# Altium schematic sheet ADC - Connectors.SchDoc
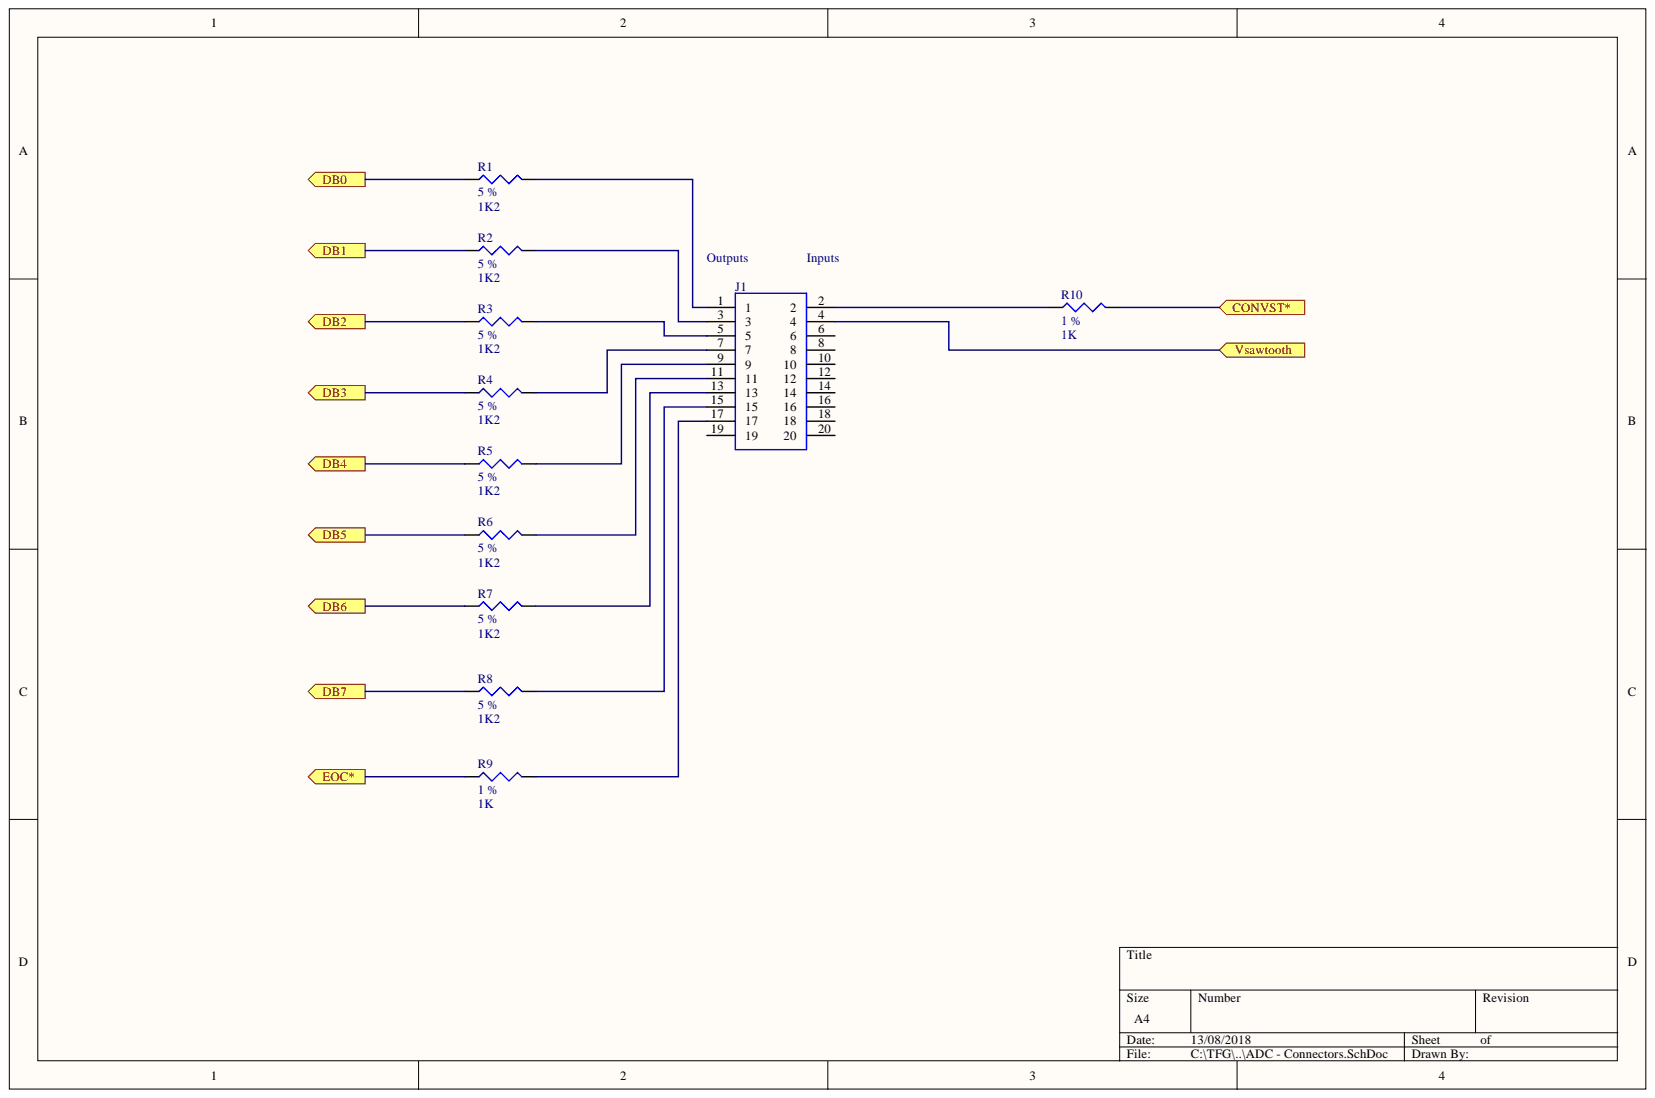
[diagram p0: ADC - Connectors.SchDoc]

## Parts
J1  IDC Connector  [IDC Connector]  (value not drawn)
R1  5 %  value 1K2  [Resistance (MM:1005) (IM: 0402)]
R2  5 %  value 1K2  [Resistance (MM:1005) (IM: 0402)]
R3  5 %  value 1K2  [Resistance (MM:1005) (IM: 0402)]
R4  5 %  value 1K2  [Resistance (MM:1005) (IM: 0402)]
R5  5 %  value 1K2  [Resistance (MM:1005) (IM: 0402)]
R6  5 %  value 1K2  [Resistance (MM:1005) (IM: 0402)]
R7  5 %  value 1K2  [Resistance (MM:1005) (IM: 0402)]
R8  5 %  value 1K2  [Resistance (MM:1005) (IM: 0402)]
R9  1 %  value 1K  [Resistance (MM:1005) (IM: 0402)]
R10  1 %  value 1K  [Resistance (MM:1005) (IM: 0402)]

## Ports
CONVST*, DB0, DB1, DB2, DB3, DB4, DB5, DB6, DB7, EOC*, Vsawtooth

## Nets
CONVST*: R10.2 ; port CONVST*
DB0: R1.1 ; port DB0
DB1: R2.1 ; port DB1
DB2: R3.1 ; port DB2
DB3: R4.1 ; port DB3
DB4: R5.1 ; port DB4
DB5: R6.1 ; port DB5
DB6: R7.1 ; port DB6
DB7: R8.1 ; port DB7
EOC*: R9.1 ; port EOC*
Vsawtooth: J1.4 ; port Vsawtooth
NetJ1_1: J1.1 R1.2
NetJ1_2: J1.2 R10.1
NetJ1_3: J1.3 R2.2
NetJ1_5: J1.5 R3.2
NetJ1_7: J1.7 R4.2
NetJ1_9: J1.9 R5.2
NetJ1_11: J1.11 R6.2
NetJ1_13: J1.13 R7.2
NetJ1_15: J1.15 R8.2
NetJ1_17: J1.17 R9.2

Not connected: J1 6 8 10 12 14 16 18 19 20

## Library data of the parts
J1 = IDC Connector: RS Pro SCP Series, Through Hole IDC Connector Plug, 20 Way, 2 Row, 2.54mm Pitch; Manufacturer_Name: RS Components; Manufacturer_Part_Number: 6257296; Geometry.Height: 8.9mm; Component Kind: Standard; Datasheet Link: https://componentsearchengine.com/Datasheets/3/6257296.pdf; Library Name: ADC Library.SchLib; RS Part Number: 6257296
R1-R10 = Res3: Resistor; Component Kind: Standard; LatestRevisionDate: 29-May-2009; LatestRevisionNote: IPC-7351 Footprint Added.; Library Name: Miscellaneous Devices.IntLib; PackageDescription: Chip Resistor; PackageReference: J1-0603; Published: 8-Jun-2000; Signal Integrity: Res; Simulation: RESISTOR; Publisher: Altium Limited
